# Altium schematic sheet CDU_Serial_Comms.SchDoc(CDU_Serial_Comms)
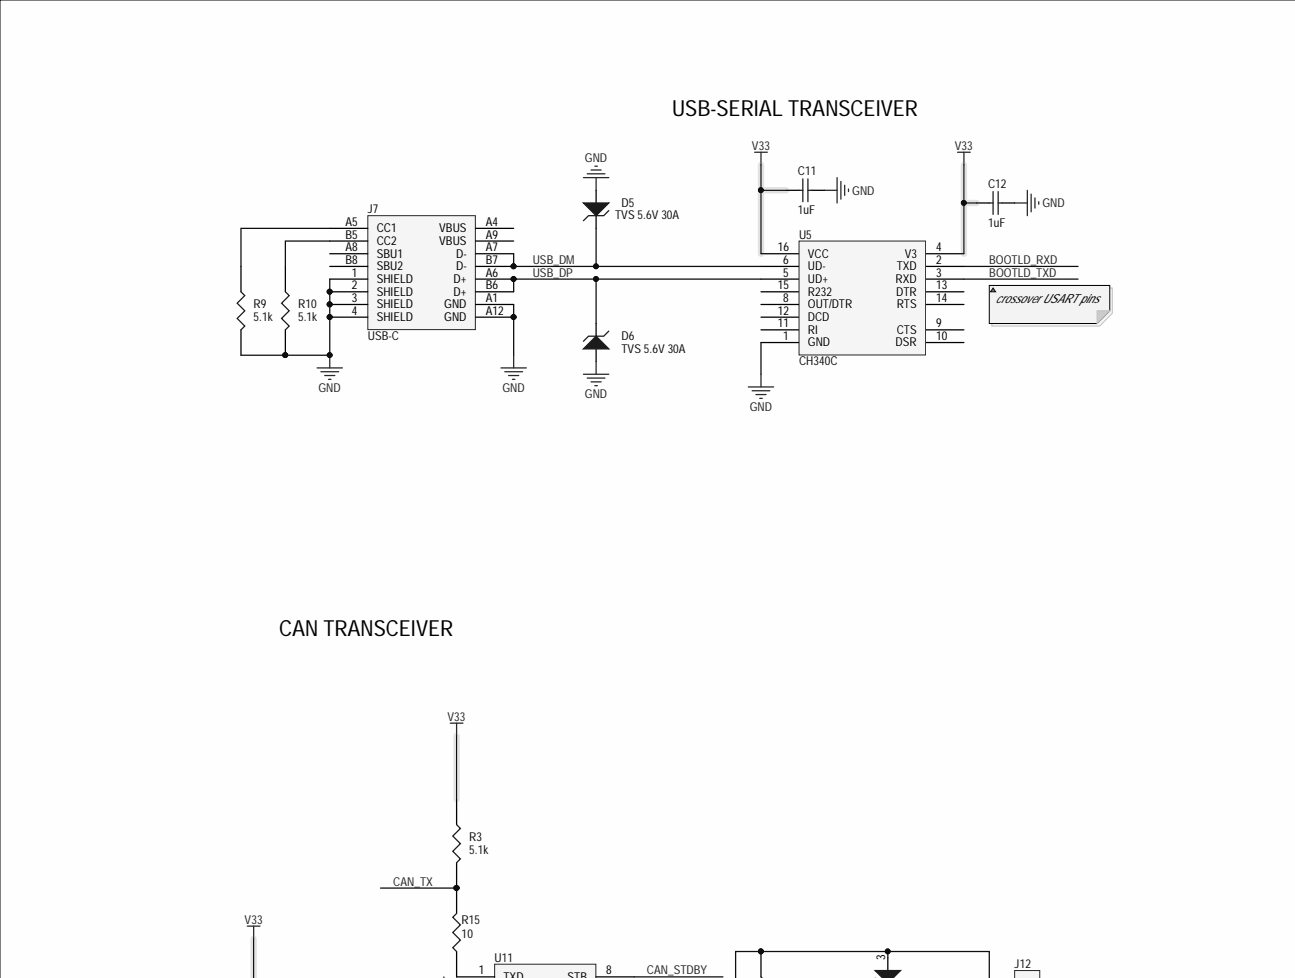
[diagram p0: CDU_Serial_Comms.SchDoc, top left]
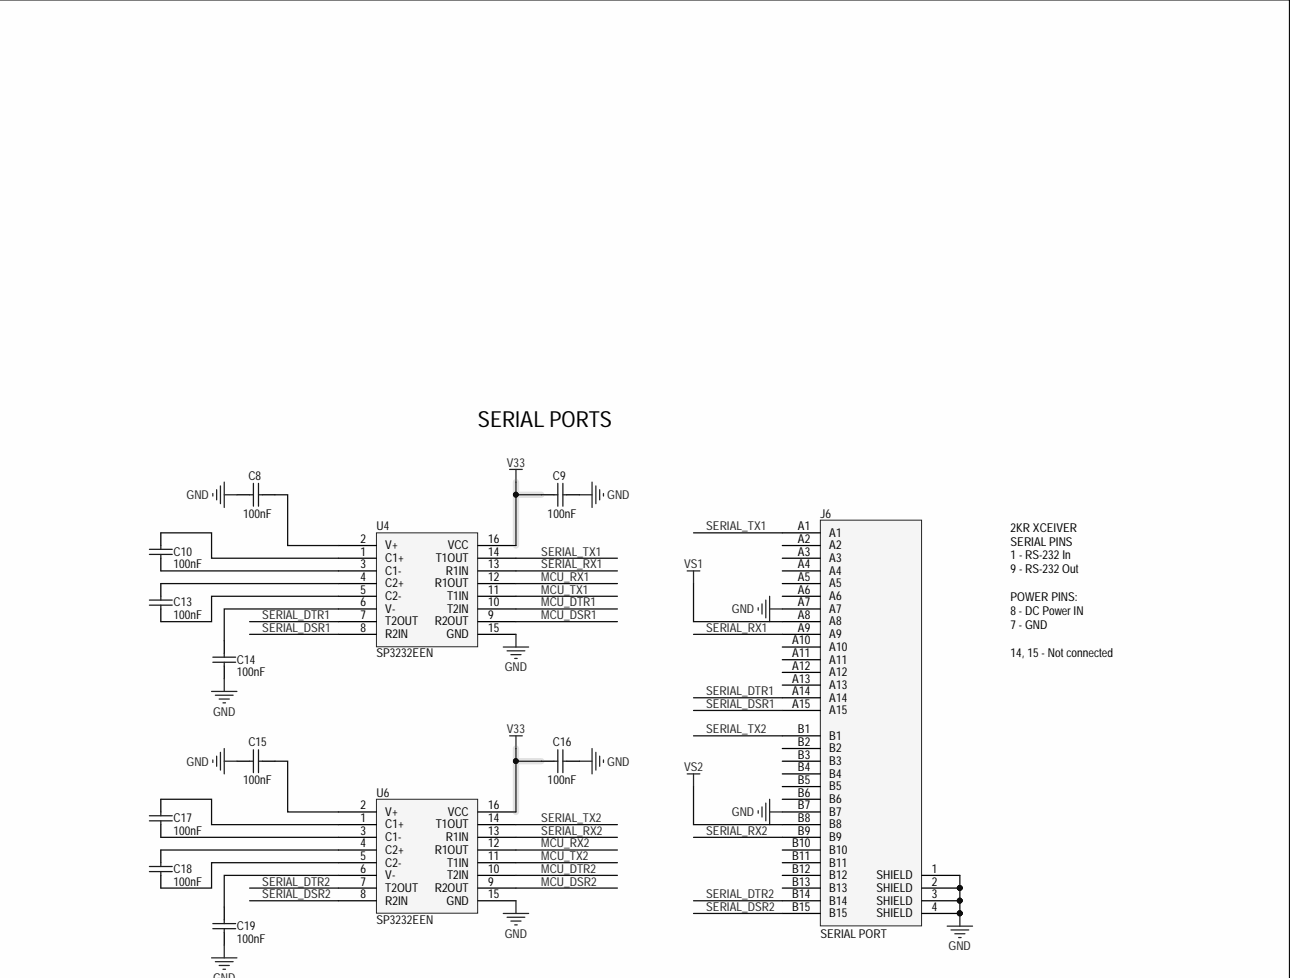
[diagram p1: CDU_Serial_Comms.SchDoc, top right]
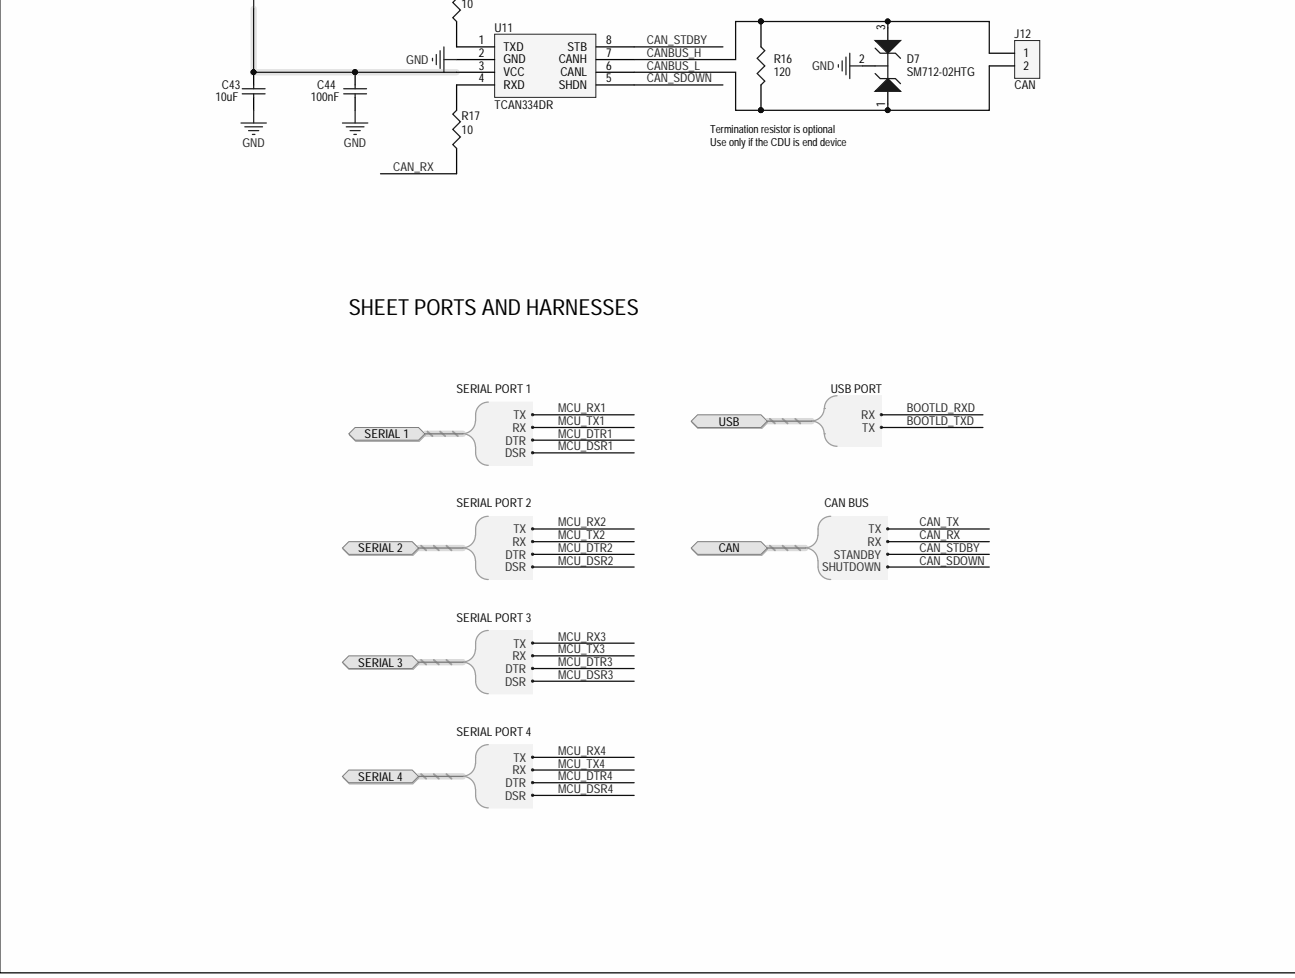
[diagram p2: CDU_Serial_Comms.SchDoc, bottom left]
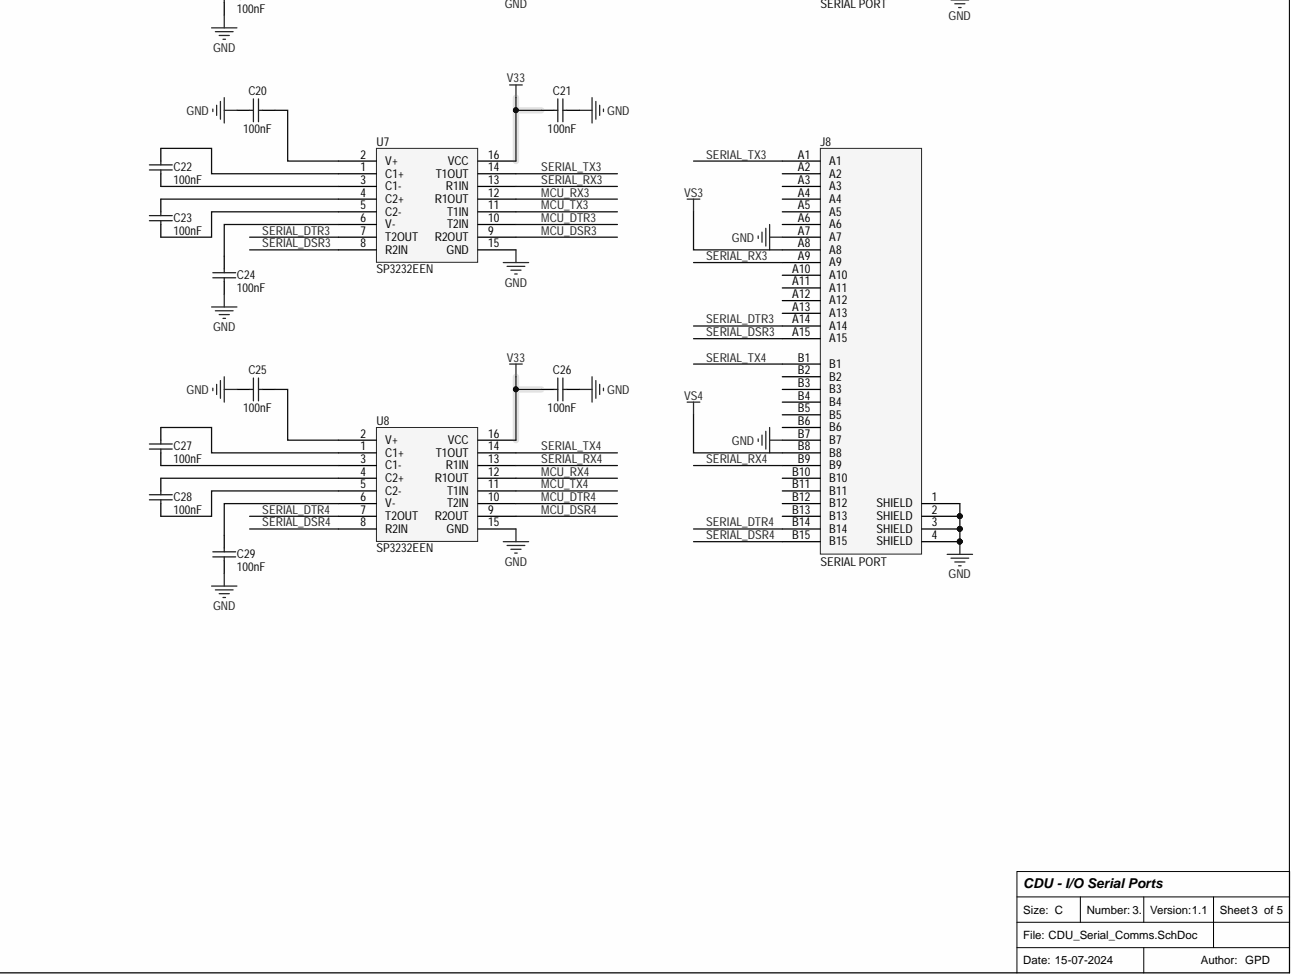
[diagram p3: CDU_Serial_Comms.SchDoc, bottom right]

## Parts
C8  100nF  [C0402]
C9  100nF  [C0402]
C10  100nF  [C0402]
C11  1uF  [C0402]
C12  1uF  [C0402]
C13  100nF  [C0402]
C14  100nF  [C0402]
C15  100nF  [C0402]
C16  100nF  [C0402]
C17  100nF  [C0402]
C18  100nF  [C0402]
C19  100nF  [C0402]
C20  100nF  [C0402]
C21  100nF  [C0402]
C22  100nF  [C0402]
C23  100nF  [C0402]
C24  100nF  [C0402]
C25  100nF  [C0402]
C26  100nF  [C0402]
C27  100nF  [C0402]
C28  100nF  [C0402]
C29  100nF  [C0402]
C43  10uF  [C1206]
C44  100nF  [C0402]
D5  TVS 5.6V 30A  [SOD523]
D6  TVS 5.6V 30A  [SOD523]
D7  SM712-02HTG  [SOT23_MBR4148]
J6  SERIAL PORT  [DB15_DUAL_1]
J7  USB-C  [USB_C_2]
J8  SERIAL PORT  [DB15_DUAL_1]
J12  CAN  [SIP2]
R3  5.1k  [R0402]
R9  5.1k  [R0402]
R10  5.1k  [R0402]
R15  10  [R0402]
R16  120  [R1206]
R17  10  [R0402]
U4  SP3232EEN  [SO-G16]
U5  CH340C  [SOP16]
U6  SP3232EEN  [SO-G16]
U7  SP3232EEN  [SO-G16]
U8  SP3232EEN  [SO-G16]
U11  TCAN334DR  [SO-G8]

## Ports
CAN, CAN.RX, CAN.SHUTDOWN, CAN.STANDBY, CAN.TX, SERIAL 1, SERIAL 1.DSR, SERIAL 1.DTR, SERIAL 1.RX, SERIAL 1.TX, SERIAL 2, SERIAL 2.DSR, SERIAL 2.DTR, SERIAL 2.RX, SERIAL 2.TX, SERIAL 3, SERIAL 3.DSR, SERIAL 3.DTR, SERIAL 3.RX, SERIAL 3.TX, SERIAL 4, SERIAL 4.DSR, SERIAL 4.DTR, SERIAL 4.RX, SERIAL 4.TX, USB, USB.RX, USB.TX

## Nets
GND: C8.2 C9.1 C11.2 C12.2 C14.2 C15.2 C16.1 C19.2 C20.2 C21.1 C24.2 C25.2 C26.1 C29.2 C43.2 C44.2 D5.1 D6.1 D7.2 J6.1(SHIELD) J6.2(SHIELD) J6.3(SHIELD) J6.4(SHIELD) J6.A7 J6.B7 J7.1(SHIELD) J7.2(SHIELD) J7.3(SHIELD) J7.4(SHIELD) J7.A1(GND) J7.A12(GND) J8.1(SHIELD) J8.2(SHIELD) J8.3(SHIELD) J8.4(SHIELD) J8.A7 J8.B7 R9.2 R10.2 U4.15(GND) U5.1(GND) U6.15(GND) U7.15(GND) U8.15(GND) U11.2(GND)
V33: C9.2 C11.1 C12.1 C16.2 C21.2 C26.2 C43.1 C44.1 R3.1 U4.16(VCC) U5.4(V3) U5.16(VCC) U6.16(VCC) U7.16(VCC) U8.16(VCC) U11.3(VCC)
VS1: J6.A8
VS2: J6.B8
VS3: J8.A8
VS4: J8.B8
BOOTLD_RXD: U5.2(TXD) ; port USB
BOOTLD_TXD: U5.3(RXD) ; port USB
CAN_RX: R17.1 ; port CAN
CAN_SDOWN: U11.5(SHDN) ; port CAN
CAN_STDBY: U11.8(STB) ; port CAN
CAN_TX: R3.2 R15.2 ; port CAN
CANBUS_H: D7.3 J12.1 R16.1 U11.7(CANH)
CANBUS_L: D7.1 J12.2 R16.2 U11.6(CANL)
MCU_DSR1: U4.9(R2OUT) ; port SERIAL 1
MCU_DSR2: U6.9(R2OUT) ; port SERIAL 2
MCU_DSR3: U7.9(R2OUT) ; port SERIAL 3
MCU_DSR4: U8.9(R2OUT) ; port SERIAL 4
MCU_DTR1: U4.10(T2IN) ; port SERIAL 1
MCU_DTR2: U6.10(T2IN) ; port SERIAL 2
MCU_DTR3: U7.10(T2IN) ; port SERIAL 3
MCU_DTR4: U8.10(T2IN) ; port SERIAL 4
MCU_RX1: U4.12(R1OUT) ; port SERIAL 1
MCU_RX2: U6.12(R1OUT) ; port SERIAL 2
MCU_RX3: U7.12(R1OUT) ; port SERIAL 3
MCU_RX4: U8.12(R1OUT) ; port SERIAL 4
MCU_TX1: U4.11(T1IN) ; port SERIAL 1
MCU_TX2: U6.11(T1IN) ; port SERIAL 2
MCU_TX3: U7.11(T1IN) ; port SERIAL 3
MCU_TX4: U8.11(T1IN) ; port SERIAL 4
SERIAL_DSR1: J6.A15 U4.8(R2IN)
SERIAL_DSR2: J6.B15 U6.8(R2IN)
SERIAL_DSR3: J8.A15 U7.8(R2IN)
SERIAL_DSR4: J8.B15 U8.8(R2IN)
SERIAL_DTR1: J6.A14 U4.7(T2OUT)
SERIAL_DTR2: J6.B14 U6.7(T2OUT)
SERIAL_DTR3: J8.A14 U7.7(T2OUT)
SERIAL_DTR4: J8.B14 U8.7(T2OUT)
SERIAL_RX1: J6.A9 U4.13(R1IN)
SERIAL_RX2: J6.B9 U6.13(R1IN)
SERIAL_RX3: J8.A9 U7.13(R1IN)
SERIAL_RX4: J8.B9 U8.13(R1IN)
SERIAL_TX1: J6.A1 U4.14(T1OUT)
SERIAL_TX2: J6.B1 U6.14(T1OUT)
SERIAL_TX3: J8.A1 U7.14(T1OUT)
SERIAL_TX4: J8.B1 U8.14(T1OUT)
USB_DM: D5.2 J7.A7(D-) J7.B7(D-) U5.6(UD-)
USB_DP: D6.2 J7.A6(D+) J7.B6(D+) U5.5(UD+)
NetC8_1: C8.1 U4.2(V+)
NetC10_1: C10.1 U4.1(C1+)
NetC10_2: C10.2 U4.3(C1-)
NetC13_1: C13.1 U4.4(C2+)
NetC13_2: C13.2 U4.5(C2-)
NetC14_1: C14.1 U4.6(V-)
NetC15_1: C15.1 U6.2(V+)
NetC17_1: C17.1 U6.1(C1+)
NetC17_2: C17.2 U6.3(C1-)
NetC18_1: C18.1 U6.4(C2+)
NetC18_2: C18.2 U6.5(C2-)
NetC19_1: C19.1 U6.6(V-)
NetC20_1: C20.1 U7.2(V+)
NetC22_1: C22.1 U7.1(C1+)
NetC22_2: C22.2 U7.3(C1-)
NetC23_1: C23.1 U7.4(C2+)
NetC23_2: C23.2 U7.5(C2-)
NetC24_1: C24.1 U7.6(V-)
NetC25_1: C25.1 U8.2(V+)
NetC27_1: C27.1 U8.1(C1+)
NetC27_2: C27.2 U8.3(C1-)
NetC28_1: C28.1 U8.4(C2+)
NetC28_2: C28.2 U8.5(C2-)
NetC29_1: C29.1 U8.6(V-)
NetJ7_A5: J7.A5(CC1) R9.1
NetJ7_B5: J7.B5(CC2) R10.1
NetR15_1: R15.1 U11.1(TXD)
NetR17_2: R17.2 U11.4(RXD)

Not connected: J6 A2 A3 A4 A5 A6 A10 A11 A12 A13 B2 B3 B4 B5 B6 B10 B11 B12 B13; J7 A4(VBUS) A8(SBU1) A9(VBUS) B8(SBU2); J8 A2 A3 A4 A5 A6 A10 A11 A12 A13 B2 B3 B4 B5 B6 B10 B11 B12 B13; U5 8(OUT/DTR) 9(CTS) 10(DSR) 11(RI) 12(DCD) 13(DTR) 14(RTS) 15(R232)

## Library data of the parts
C8-C10 C13-C29 C44 = CAP: 100nF ±10% 16V X7R 0402 Multilayer Ceramic Capacitors MLCC - SMD/SMT RoHS; Manufacturer Part Number: C0402C104K4RAC-TU; Manufacturer: KEMET; Value: 100nF; Supplier Part Number 3: 399-C0402C104K4RAC7867DKR-ND; Supplier Part Number 2: 8015145; Supplier Part Number 1: 80-C0402C104K4R; Supplier 3: Digikey; Supplier 2: Rs; Supplier 1: Mouser; Library Name: Miscellaneous Devices.SchLib; Component Kind: Standard
C11 C12 = CAP: Multilayer Ceramic Capacitor, 1 uF, 10 V, ± 10%, X5R, 0402 [1005 Metric]; Manufacturer Part Number: CC0402KRX5R6BB105; Manufacturer: Yageo; Value: 1uF; Supplier Part Number 3: 603-CC402KRX5R6BB105; Supplier Part Number 2: 311-1439-6-ND; Supplier Part Number 1: 311-1439-1-ND; Supplier 3: Mouser; Supplier 2: Digikey; Supplier 1: Digikey; Library Name: Miscellaneous Devices.SchLib; Component Kind: Standard
C43 = CAP: Multilayer Ceramic Capacitor, 10 uF, 50 V, ± 20%, X5R, 1206 [3216 Metric]; Manufacturer Part Number: UMK316BBJ106ML-T; Manufacturer: Taiyo Yuden; Value: 10uF; Supplier Part Number 3: C97712; Supplier Part Number 2: 963-UMK316BBJ106ML-T; Supplier Part Number 1: 587-3248-6-ND; Supplier 3: LCSC; Supplier 2: Mouser; Supplier 1: Digikey; Library Name: Miscellaneous Devices.SchLib; Component Kind: Standard
D5 D6 = 1N5380B: Epcos B72500D0050A060; Bi-Directional TVS Diode; 1000W; 2-Pin SOD-523; Manufacturer: TDK EPCOS; Value: TVS 5.6V 30A; Supplier Part Number 3: 4962968P; Supplier Part Number 2: 495-3414-6-ND; Supplier Part Number 1: C210787; Supplier 3: Rs; Supplier 2: Digikey; Supplier 1: LCSC; Simulation: 1N5380B; Manufacturer Part Number: B72500D0050A060; Library Name: DIODE.SchLib; Component Kind: Standard
D7 = DZ89C180: SM712 Series 31 V 600 W Surface Mount Asymmetrical TVS Diode Array - SOT-23-3; Manufacturer: Littelfuse; Value: SM712-02HTG; Supplier Part Number 3: C172881; Supplier Part Number 2: F6450DKR-ND; Supplier Part Number 1: 576-SM712-02HTG; Supplier 3: LCSC; Supplier 2: Digikey; Supplier 1: Mouser; Simulation: DZ89C180; Manufacturer Part Number: SM712-02HTG; Library Name: DIODE.SchLib; Component Kind: Standard
J6 J8 = DUAL_DB15: Dual SubD 15 F; Manufacturer Part Number: L17H2442120; Manufacturer: Amphenol; Value: SERIAL PORT; Supplier Part Number 3: L17H2442120-ND; Supplier Part Number 2: 523-L17H2442120; Supplier Part Number 1: 3729472; Supplier 3: Digikey; Supplier 2: Mouser; Supplier 1: Farnell; Component Kind: Standard; Library Name: Varios.SchLib
J7 = USB-C-CONN2: USB 2.0 5A 1 Surface Mount，Right Angle 16P Female -40℃~+85℃ Gold High Conductivity Copper Type-C SMD USB Connectors ROHS; Manufacturer Part Number: GT-USB-7047B; Manufacturer: G-Switch; Value: USB-C; Supplier Part Number 3: C963217; Supplier Part Number 2: C963217; Supplier Part Number 1: C963217; Supplier 3: LCSC; Supplier 2: LCSC; Supplier 1: LCSC; Component Kind: Standard; Library Name: Varios.SchLib
J12 = CON2: Conn Unshrouded Header HDR 2 POS Solder ST Top Entry Thru-Hole; Manufacturer Part Number: 69157-102HLF; Manufacturer: Amphenol ICC / FCI; Value: CAN; Supplier Part Number 3: 649-69157-102HLF; Supplier Part Number 2: 3272976; Supplier Part Number 1: 609-5537-ND; Supplier 3: Mouser; Supplier 2: Farnell; Supplier 1: Digikey; Library Name: Miscellaneous Devices.SchLib; Component Kind: Standard
R3 R9 R10 = RES1: SMD Chip Resistor, 5.1 kOhm, ± 5%, 63 mW, 0402 [1005 Metric], Thick Film, General Purpose; Manufacturer Part Number: RC0402JR-075K1L; Manufacturer: Yageo; Value: 5.1k; Supplier Part Number 3: C105873; Supplier Part Number 2: 311-5.1KJRCT-ND; Supplier Part Number 1: 603-RC0402JR-075K1L; Supplier 3: LCSC; Supplier 2: Digikey; Supplier 1: Mouser; Library Name: Miscellaneous Devices.SchLib; Component Kind: Standard
R15 R17 = RES1: SMD Chip Resistor, 10 Ohm, ± 1%, 63 mW, 0402 [1005 Metric], Thick Film, General Purpose; Manufacturer Part Number: CR0402-FX-10R0GLF; Manufacturer: Bourns; Value: 10; Supplier Part Number 3: CR0402-FX-10R0GLFDKR-ND; Supplier Part Number 2: 652-CR0402FX-10R0GLF; Supplier Part Number 1: 4015738; Supplier 3: Digikey; Supplier 2: Mouser; Supplier 1: Farnell; Library Name: Miscellaneous Devices.SchLib; Component Kind: Standard
R16 = RES1: SMD Chip Resistor, 120 Ohm, ± 1%, 250 mW, 1206 [3216 Metric], Thick Film, General Purpose; Manufacturer Part Number: CR1206-FX-1200ELF; Manufacturer: Bourns; Value: 120; Supplier Part Number 3: 2333562; Supplier Part Number 2: 652-CR1206FX-1200ELF; Supplier Part Number 1: CR1206-FX-1200ELFDKR-ND; Supplier 3: Farnell; Supplier 2: Mouser; Supplier 1: Digikey; Library Name: Miscellaneous Devices.SchLib; Component Kind: Standard
U4 U6-U8 = MAX3232: SOP-16 RS232 ICs ROHS; Manufacturer: UMW; Value: SP3232EEN; Supplier Part Number 3: 4518-SP3232EENCT-ND; Supplier Part Number 2: 4518-SP3232EENDKR-ND; Supplier Part Number 1: C2904739; Supplier 3: Digikey; Supplier 2: Digikey; Supplier 1: LCSC; Manufacturer Part Number: SP3232EEN; Library Name: ICs.SchLib; ComponentLink1URL: https://www.lcsc.com/datasheet/lcsc_datasheet_2402231528_UMW-Youtai-Semiconductor-Co---Ltd--SP3232EEN_C2904739.pdf; ComponentLink1Description: Datasheet; Component Kind: Standard
U5 = CH340C: Transceiver 2Mbps USB 2.0 SOP-16_150mil USB Ics ROHS; Manufacturer: WCH; Value: CH340C; Supplier Part Number 3: C84681; Supplier Part Number 2: C84681; Supplier Part Number 1: C7464026; Supplier 3: LCSC; Supplier 2: LCSC; Supplier 1: LCSC; Manufacturer Part Number: CH340C; Library Name: ICs.SchLib; ComponentLink1URL: https://cdn.sparkfun.com/datasheets/Dev/Arduino/Other/CH340DS1.PDF; ComponentLink1Description: Datasheet; Component Kind: Standard
U11 = PIC12F629/675: EAR99 TCAN334 Tape & Reel (TR) CANbus interface driver 120mV 1.75mm 1Mbps -40C~125C; Manufacturer: Texas Instruments; Value: TCAN334DR; Supplier Part Number 3: 296-44139-1-ND; Supplier Part Number 2: TCAN334DR; Supplier Part Number 1: C2871143; Supplier 3: Digikey; Supplier 2: Texas Instruments; Supplier 1: LCSC; Manufacturer Part Number: TCAN334DR; Library Name: PICs.SchLib; ComponentLink2URL: http://www.ti.com/lit/ds/symlink/tcan330.pdf; ComponentLink2Description: Datasheet; Component Kind: Standard
